# Altium schematic sheet 6507.SchDoc
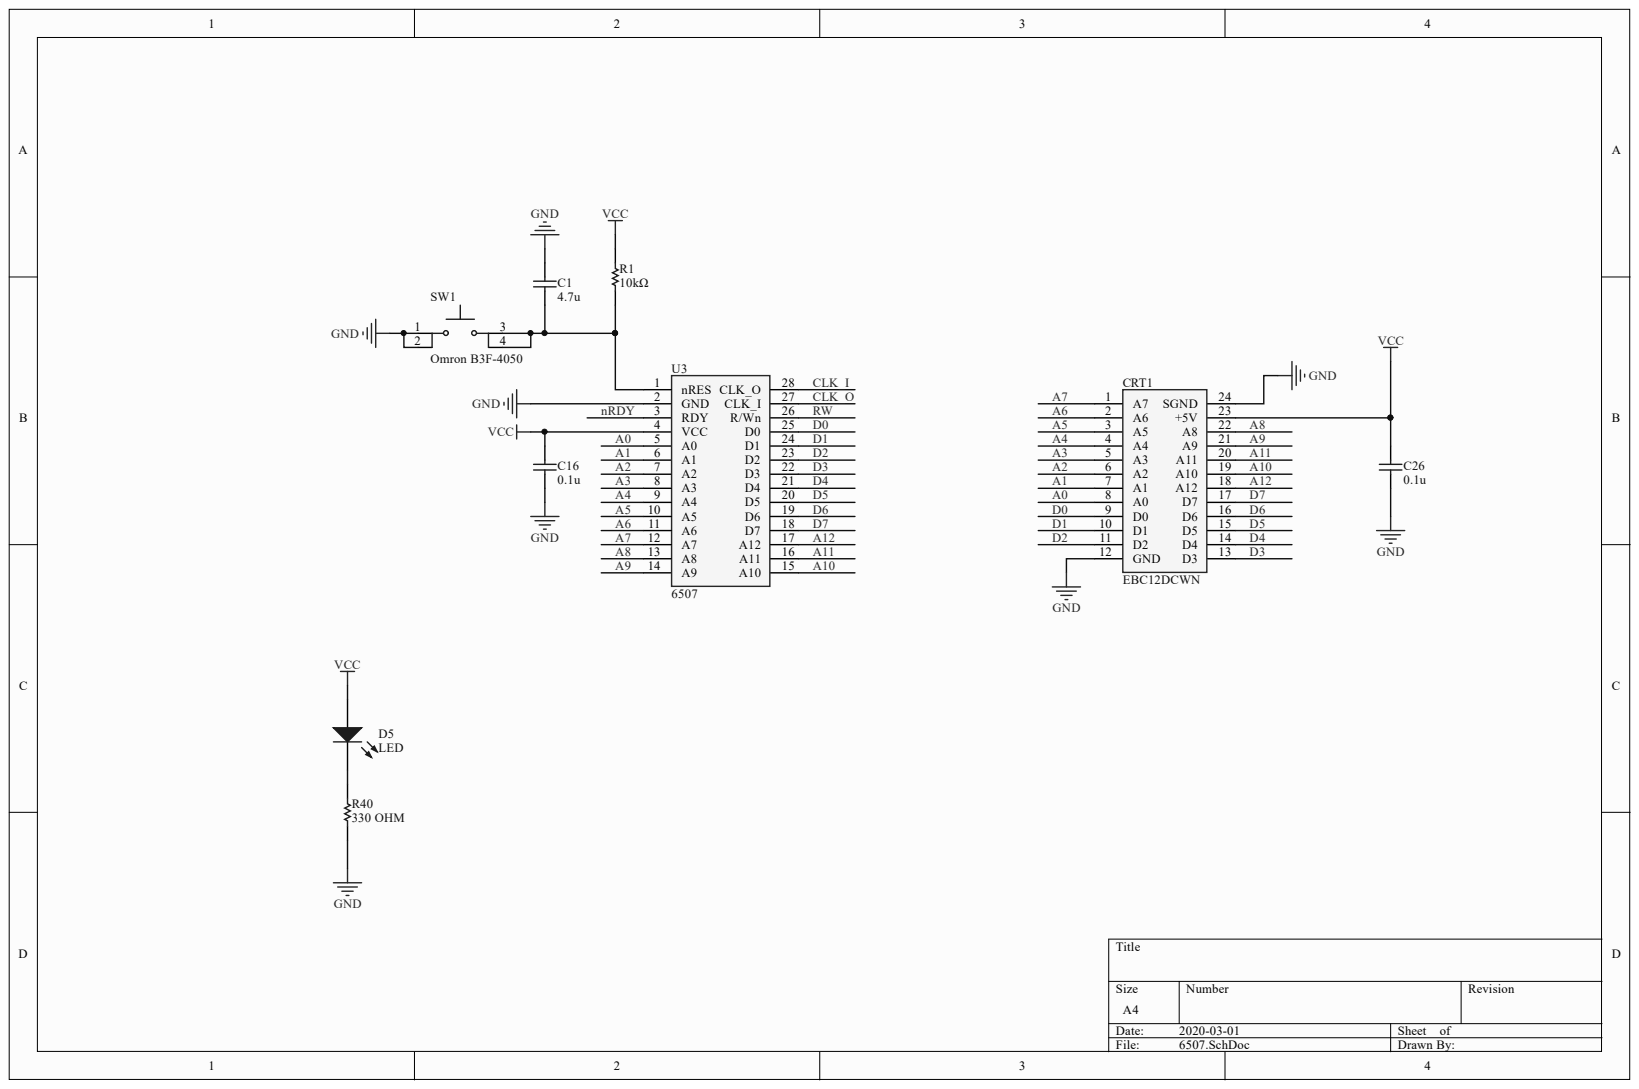
[diagram p0: 6507.SchDoc]

## Parts
C1  Capacitance: 4.7u  [CAPC2013X140X45ML15T25]  (hidden comment: Murata GCM21BR71E104KA37L)
C16  Capacitance: 0.1u  [CAPC2013X140X45ML15T25]  (hidden comment: Murata GCM21BR71E104KA37L)
C26  Capacitance: 0.1u  [CAPC2013X140X45ML15T25]  (hidden comment: Murata GCM21BR71E104KA37L)
CRT1  EBC12DCWN  [PCB-0i6t8ykr9ezj900pucxr-1]
D5  LED  [PCB-7mgmpbi0oy4qklr35z73-1]
R1  Resistance: 10kΩ  [PCB-jqva0n1m8ejtn3mkjxws-1]  (hidden comment: TE Connectivity LR1F10K)
R40  330 OHM  [PCB-l1knixslc5nxcetaqmrd-1]
SW1  Omron B3F-4050  [PCB-f7mxrp64k8suv8d5sj09-1]
U3  6507  [PCB-47z3ky80qp1z4c8tz9fr-1]

## Nets
GND: C1.1 C16.1 C26.1 CRT1.12(GND) CRT1.24(SGND) R40.1 SW1.1 SW1.2 U3.2(GND)
VCC: C16.2 C26.2 CRT1.23(+5V) D5.1 R1.2 U3.4(VCC)
A0: CRT1.8(A0) U3.5(A0)
A1: CRT1.7(A1) U3.6(A1)
A2: CRT1.6(A2) U3.7(A2)
A3: CRT1.5(A3) U3.8(A3)
A4: CRT1.4(A4) U3.9(A4)
A5: CRT1.3(A5) U3.10(A5)
A6: CRT1.2(A6) U3.11(A6)
A7: CRT1.1(A7) U3.12(A7)
A8: CRT1.22(A8) U3.13(A8)
A9: CRT1.21(A9) U3.14(A9)
A10: CRT1.19(A10) U3.15(A10)
A11: CRT1.20(A11) U3.16(A11)
A12: CRT1.18(A12) U3.17(A12)
CLK_I: U3.28(CLK_O)
CLK_O: U3.27(CLK_I)
D0: CRT1.9(D0) U3.25(D0)
D1: CRT1.10(D1) U3.24(D1)
D2: CRT1.11(D2) U3.23(D2)
D3: CRT1.13(D3) U3.22(D3)
D4: CRT1.14(D4) U3.21(D4)
D5: CRT1.15(D5) U3.20(D5)
D6: CRT1.16(D6) U3.19(D6)
D7: CRT1.17(D7) U3.18(D7)
NRDY: U3.3(RDY)
RW: U3.26(R/Wn)
NetC1_2: C1.2 R1.1 SW1.3 SW1.4 U3.1(nRES)
NetD5_2: D5.2 R40.2

## Library data of the parts
C1 = CMP-135214-2: CAP CER 4.7UF 25V 10% X7R 0805; Voltage Rating (DC): 25.0 V; Capacitance Tolerance: ±10%; Case/Package: 0805; Component Kind: Standard; Dielectric Characteristic: X7R; Library Name: CommunityVault; OctopartID: e78b2f8c9518384c; Octopart Url: https://octopart.com/gcm21br71e104ka37l-murata-17651701; RoHS: Compliant
C16 C26 = CMP-135214-2: CAP CER 0.1UF 25V 10% X7R 0805; Voltage Rating (DC): 25.0 V; Capacitance Tolerance: ±10%; Case/Package: 0805; Component Kind: Standard; Dielectric Characteristic: X7R; Library Name: CommunityVault; OctopartID: e78b2f8c9518384c; Octopart Url: https://octopart.com/gcm21br71e104ka37l-murata-17651701; RoHS: Compliant
CRT1 = CMP-4l5wkvrgyfcc67tow1zw-1: Sullins Cardge Edge, 24 position; Component Kind: Standard; CustomComponentID: 4l5wkvrgyfcc67tow1zw; Library Name: FavoritesLibrary
D5 = CMP-99d352841a854614-2: LED; 5mm; green; 40-50mcd; 14°; Front: convex; Pitch: 2.54mm; Manufacturer Part Number: TLHG5205; Peak Wavelength: 565 nm; Color: Green; Component Kind: Standard; Library Name: CommunityVault; Mounting Style: Through Hole, Radial; Number of Pins: 2
R1 = CMP-0a5cc3a37cecd59b-6: Res Metal Film 10K Ohm 1% 3/5W ±50ppm/°C; ELV Compliant: Compliant; Component Kind: Standard; Library Name: CommunityVault; Manufacturer Part Number: LR1F10K; Packaging: Ammo Pack; REACH SVHC Compliance: No SVHC; RoHS: Compliant
R40 = CMP-440a8c3647749bcd-1: MBB0207 Series Axial Thin Film Resistor0Ohms +/-1% 0.6W +/-50ppm/degC; Manufacturer Part Number: MBB02070Z0000ZCT00; Lead-Free Status: Lead Free; Component Kind: Standard; Library Name: CommunityVault; Mounting Style: Axial; Packaging: Ammo Pack; Size-Length: 6.50 mm
SW1 = CMP-0554cc5e77dcda93-1: Switch, Flux tight Mechanical Keyswitch, , 50MA@24VDC, No Ground Term., Flat Plung.; Actuator Type: Standard; Contact Current Rating: 50.0 mA; Contact Resistance: 100 mΩ; Component Kind: Standard; Contact Material: Phosphor Bronze; Contact Plating: Silver; Library Name: CommunityVault; REACH SVHC Compliance: No SVHC; Size-Width: 12.0 mm
U3 = CMP-4p2sz4bojct3psrmgjz4-1: 6507 8-bit processor; Component Kind: Standard; CustomComponentID: 4p2sz4bojct3psrmgjz4; Library Name: FavoritesLibrary
